# Altium schematic sheet Tag.SchDoc
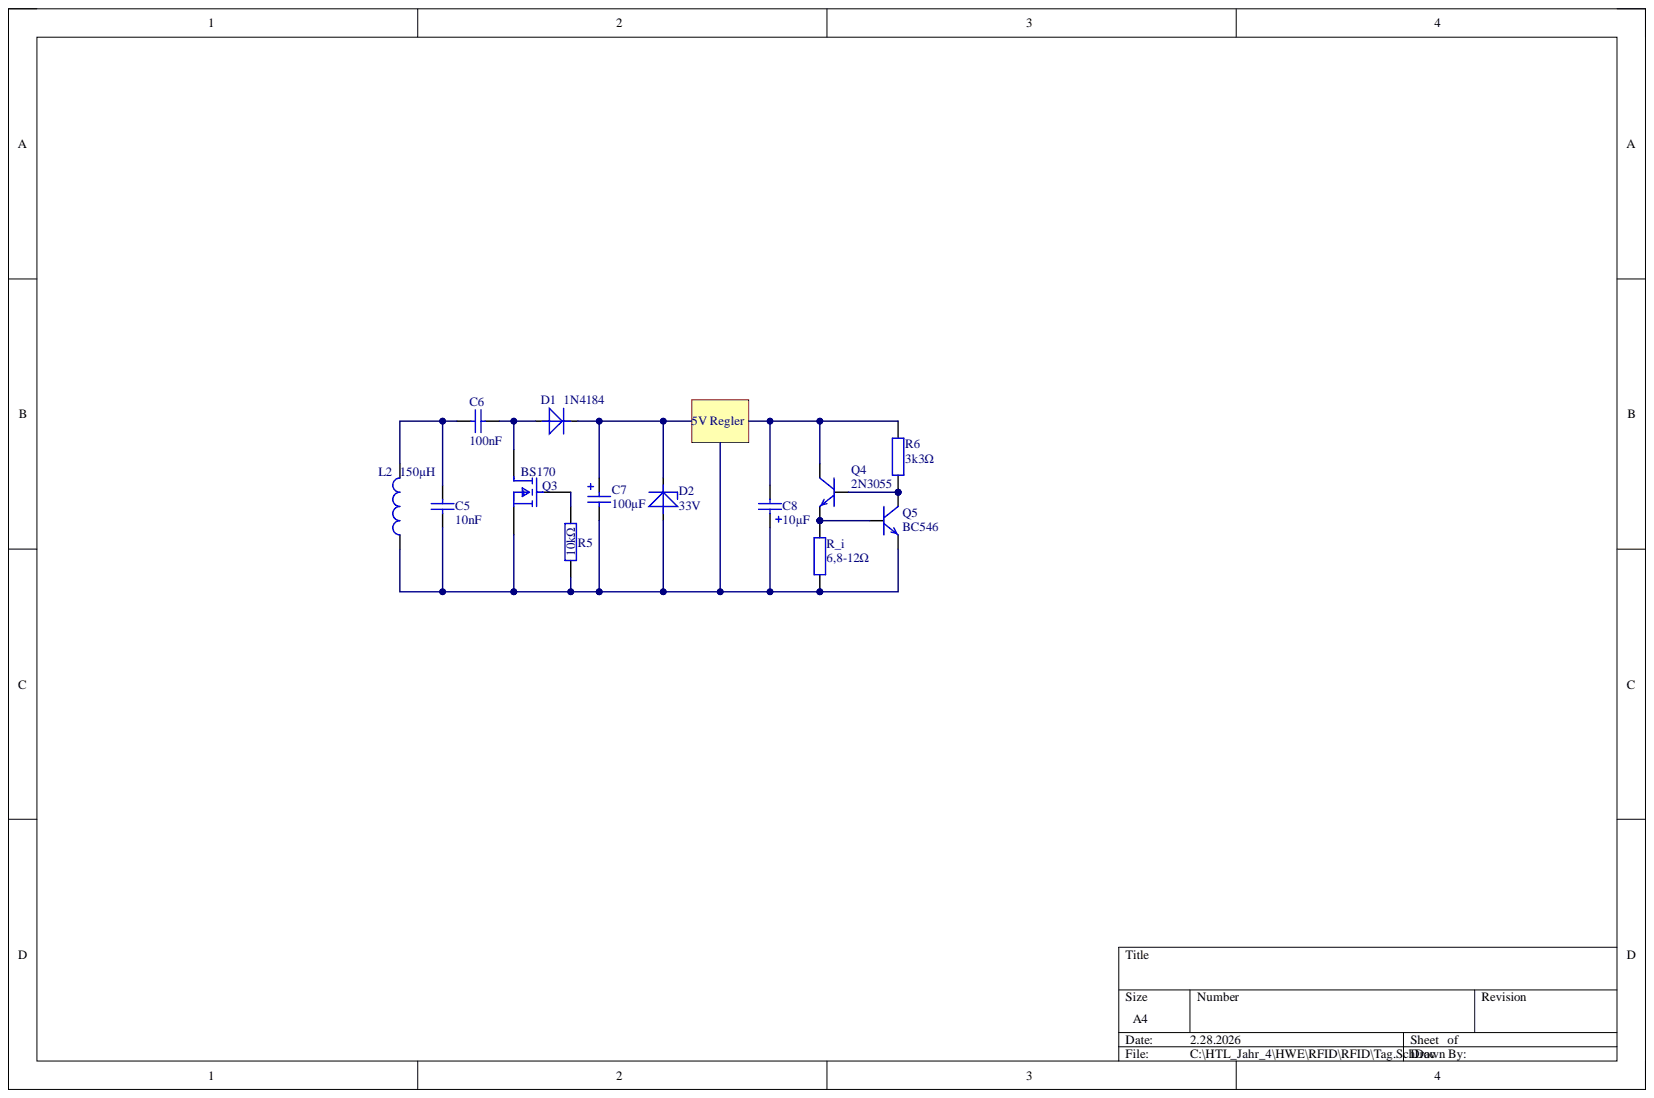
[diagram p0: Tag.SchDoc]

## Parts
C5  value 10nF  [rad-7.2/2.5/5]  (hidden comment: KO)
C6  value 100nF  [rad-7.2/2.5/5]  (hidden comment: KO)
C7  value 100µF  [RB-.1/.2]  (hidden comment: ELKO)
C8  value 10µF  [RB-.1/.2]  (hidden comment: ELKO)
D1  1N4184  [DIODE-0.6]
D2  33V  [DIODE-0.4]
L2  value 150µH  (hidden comment: Inductor)
Q3  BS170  [FP-SOT23-IPC_A]
Q4  2N3055  [TO-92/EBC]
Q5  BC546  [TO-92/EBC]
R5  value 10kΩ  [Axial-0.4]  (hidden comment: RES)
R6  value 3k3Ω  [Axial-0.4]  (hidden comment: RES)
R_i  value 6,8-12Ω  [Axial-0.4]  (hidden comment: RES)

## Nets
NetC5_1: C5.1 C7.2 C8.1 D2.1 L2.2 Q3.2 Q5.2 R5.1 R_i.1
NetC5_2: C5.2 C6.2 L2.1
NetC6_1: C6.1 D1.1 Q3.3
NetC7_1: C7.1 D1.2 D2.2
NetC8_2: C8.2 Q4.3 R6.2
NetQ3_G1: Q3.G1 R5.2
NetQ4_1: Q4.1 Q5.3 R6.1
NetQ4_2: Q4.2 Q5.1 R_i.2

## Library data of the parts
C5 C6 = KO: Kondensator; Component Kind: Standard; Library Name: HTL-sym-2021_04.schlib; Simulation: Model Name
D1 = DIODE: Component Kind: Standard; Description: Diode; Library Name: HTL-sym-2021_04.schlib
D2 = Z-DIODE: Component Kind: Standard; Description: Z-Diode; Library Name: HTL-sym-2021_04.schlib
L2 = Inductor: SimulationGenericComponent: True; Component Kind: Standard; Description: Inductor; Library Name: Simulation Generic Components.IntLib; Simulation: L_Inductor_Default
Q3 = CMP-12801-000064-2: MOSFET N-CH 60V .15MA SOT23-3; Continuous Drain Current (ID): 150mA; Drain to Source Resistance: 5R; Element Configuration: Single; Gate to Source Voltage (Vgs): 20V; Input Capacitance: 60pF; Max Power Dissipation: 330mW; Nominal Vgs: 3V; Number of Channels: 1; Turn-Off Delay Time: 10ns; Case/Package: SOT-23; Component Kind: Standard; Contact Plating: Tin; Current Rating: 150mA; Drain to Source Breakdown Voltage: 60V; Drain to Source Voltage (Vdss): 60V; Dual Supply Voltage: 60V; Height: 1mm; Lead Free: Lead Free; Length: 3.05mm; Library Name: Components - 01.04.25 - 10.32.SchLib; Max Operating Temperature: 150°C; Min Operating Temperature: -55°C; Mount: Surface Mount; Number of Elements: 1; Number of Pins: 3; On-State Resistance: 5R; Package Quantity: 3000; Packaging: Tape and Reel; Power Dissipation: 330mW; Rds On Max: 5R; REACH SVHC: No SVHC; Termination: SMD/SMT; Threshold Voltage: 3V; Turn-On Delay Time: 10ns; Voltage Rating (DC): 60V; Weight: 0.000282oz; Width: 1.4mm
Q4 Q5 = NPN: Transistor; Component Kind: Standard; Library Name: HTL-sym-2021_04.schlib
R5 R6 R_i = RES: Widerstand; Component Kind: Standard; Library Name: HTL-sym-2021_04.schlib; Simulation: Model Name
C7 C8 = ELKO: Component Kind: Standard; Description: Elko; Library Name: HTL-sym-2021_04.schlib; Simulation: Model Name
